# Altium schematic sheet 12V_Efuses2.SchDoc(12V_Efuses2)
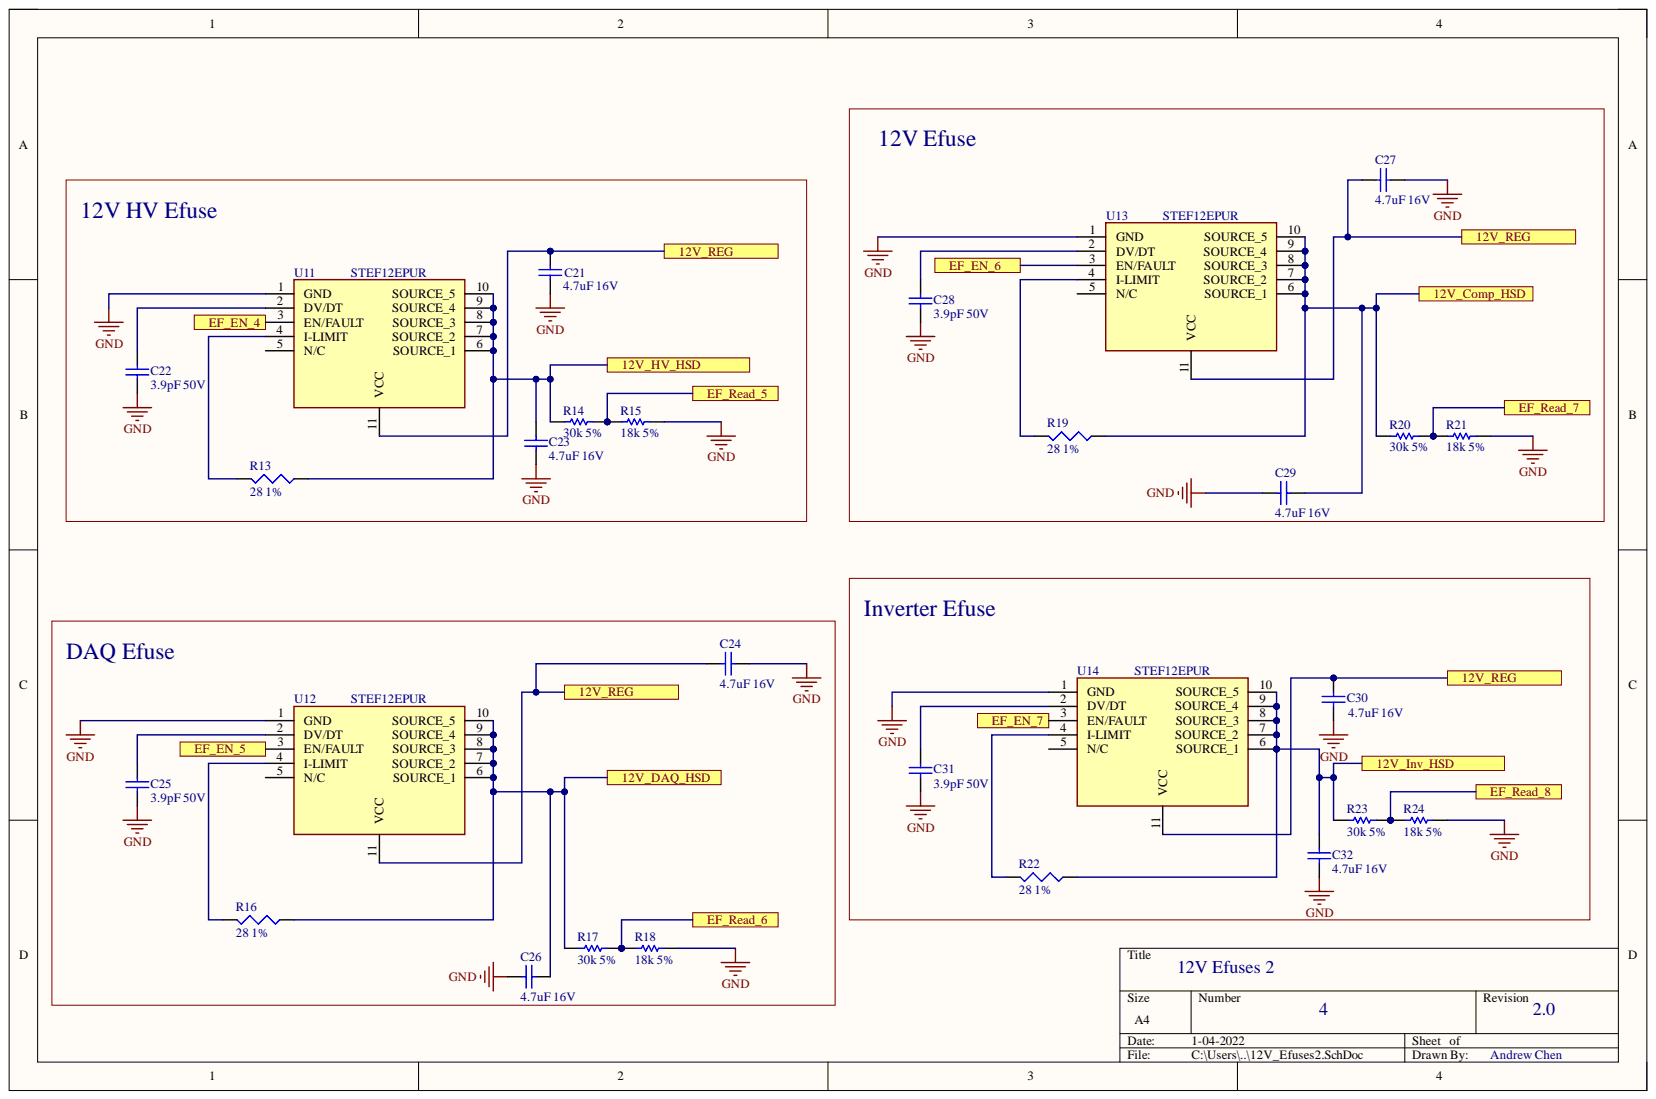
[diagram p0: 12V_Efuses2.SchDoc]

## Parts
C21  4.7uF 16V  [C1206]
C22  3.9pF 50V  [C1206]
C23  4.7uF 16V  [C1206]
C24  4.7uF 16V  [C1206]
C25  3.9pF 50V  [C1206]
C26  4.7uF 16V  [C1206]
C27  4.7uF 16V  [C1206]
C28  3.9pF 50V  [C1206]
C29  4.7uF 16V  [C1206]
C30  4.7uF 16V  [C1206]
C31  3.9pF 50V  [C1206]
C32  4.7uF 16V  [C1206]
R13  28 1%  [J1-0603]
R14  30k 5%  [RESC1609X50X30NL8T20]
R15  18k 5%  [RESC1609X50X30NL8T20]
R16  28 1%  [J1-0603]
R17  30k 5%  [RESC1609X50X30NL8T20]
R18  18k 5%  [RESC1609X50X30NL8T20]
R19  28 1%  [J1-0603]
R20  30k 5%  [RESC1609X50X30NL8T20]
R21  18k 5%  [RESC1609X50X30NL8T20]
R22  28 1%  [J1-0603]
R23  30k 5%  [RESC1609X50X30NL8T20]
R24  18k 5%  [RESC1609X50X30NL8T20]
U11  STEF12EPUR  [SON50P300X300X100-11N-D]
U12  STEF12EPUR  [SON50P300X300X100-11N-D]
U13  STEF12EPUR  [SON50P300X300X100-11N-D]
U14  STEF12EPUR  [SON50P300X300X100-11N-D]

## Ports
12V_Comp_HSD, 12V_DAQ_HSD, 12V_HV_HSD, 12V_Inv_HSD, 12V_REG, EF_EN_4, EF_EN_5, EF_EN_6, EF_EN_7, EF_Read_5, EF_Read_6, EF_Read_7, EF_Read_8

## Nets
GND: C21.2 C22.2 C23.2 C24.1 C25.2 C26.2 C27.1 C28.2 C29.2 C30.2 C31.2 C32.2 R15.2 R18.2 R21.2 R24.2 U11.1(GND) U12.1(GND) U13.1(GND) U14.1(GND)
12V_Comp_HSD: C29.1 R19.1 R20.1 U13.6(SOURCE_1) U13.7(SOURCE_2) U13.8(SOURCE_3) U13.9(SOURCE_4) U13.10(SOURCE_5) ; port 12V_Comp_HSD
12V_DAQ_HSD: C26.1 R16.1 R17.1 U12.6(SOURCE_1) U12.7(SOURCE_2) U12.8(SOURCE_3) U12.9(SOURCE_4) U12.10(SOURCE_5) ; port 12V_DAQ_HSD
12V_HV_HSD: C23.1 R13.1 R14.1 U11.6(SOURCE_1) U11.7(SOURCE_2) U11.8(SOURCE_3) U11.9(SOURCE_4) U11.10(SOURCE_5) ; port 12V_HV_HSD
12V_Inv_HSD: C32.1 R22.1 R23.1 U14.6(SOURCE_1) U14.7(SOURCE_2) U14.8(SOURCE_3) U14.9(SOURCE_4) U14.10(SOURCE_5) ; port 12V_Inv_HSD
12V_REG: C21.1 C24.2 C27.2 C30.1 U11.11(VCC) U12.11(VCC) U13.11(VCC) U14.11(VCC) ; port 12V_REG
EF_EN_4: U11.3(EN/FAULT) ; port EF_EN_4
EF_EN_5: U12.3(EN/FAULT) ; port EF_EN_5
EF_EN_6: U13.3(EN/FAULT) ; port EF_EN_6
EF_EN_7: U14.3(EN/FAULT) ; port EF_EN_7
EF_Read_5: R14.2 R15.1 ; port EF_Read_5
EF_Read_6: R17.2 R18.1 ; port EF_Read_6
EF_Read_7: R20.2 R21.1 ; port EF_Read_7
EF_Read_8: R23.2 R24.1 ; port EF_Read_8
NetC22_1: C22.1 U11.2(DV/DT)
NetC25_1: C25.1 U12.2(DV/DT)
NetC28_1: C28.1 U13.2(DV/DT)
NetC31_1: C31.1 U14.2(DV/DT)
NetR13_2: R13.2 U11.4(I-LIMIT)
NetR16_2: R16.2 U12.4(I-LIMIT)
NetR19_2: R19.2 U13.4(I-LIMIT)
NetR22_2: R22.2 U14.4(I-LIMIT)

Not connected: U11 5(N/C); U12 5(N/C); U13 5(N/C); U14 5(N/C)

## Library data of the parts
C21-C32 = Cap Semi: Capacitor (Semiconductor SIM Model); Value: 22pF; Component Kind: Standard; LatestRevisionDate: 29-May-2009; LatestRevisionNote: IPC-7351 Footprint Added.; Library Name: Miscellaneous Devices.IntLib; PackageDescription: Chip Capacitor; PackageReference: C1206; Published: 8-Jun-2000; Signal Integrity: Cap Semi; Simulation: CAP; Publisher: Altium Limited
R13 R16 R19 R22 = Res3: Resistor; Value: 220; Component Kind: Standard; LatestRevisionDate: 29-May-2009; LatestRevisionNote: IPC-7351 Footprint Added.; Library Name: Miscellaneous Devices.IntLib; PackageDescription: Chip Resistor; PackageReference: J1-0603; Published: 8-Jun-2000; Signal Integrity: Res; Simulation: RESISTOR; Publisher: Altium Limited
R14 R15 R17 R18 R20 R21 R23 R24 = CMP-2000-03816-5: CRCW06030000Z0EA; Manufacturer: Vishay Semiconductor; Voltage Rating: 75 V; Component Kind: Standard; ComponentLink1Description: Manufacturer URL; ComponentLink1URL: http://www.vishay.com/; ComponentLink2Description: Datasheet; ComponentLink2URL: http://www.vishay.com/docs/20035/dcrcwe3.pdf; DatasheetVersion: 06/2012; Height: 0.45 mm; Length: 1.55 mm; Library Name: Altium Content Vault; Max Operating Temperature: 155 degC; Min Operating Temperature: -55 degC; Mount: Surface Mount; Mounting Technology: Surface Mount; Package Quantity: 5000; PackageDescription: 2-Pin Surface Mount Device, Body 1.575 x 0.85 mm; PackageReference: 0603; Packaging: Tape and Reel; Pins: 2; REACH SVHC: Unknown; RoHSCompliant: true
U11-U14 = STEF12EPUR: Integrated Circuit; Manufacturer_Name: STMicroelectronics; Arrow Part Number: STEF12EPUR; Arrow Price/Stock: https://www.arrow.com/en/products/stef12epur/stmicroelectronics; Component Kind: Standard; Datasheet Link: https://www.arrow.com/en/products/stef12epur/stmicroelectronics; Height: 1mm; Manufacturer_Part_Number: STEF12EPUR; Mouser Part Number: 511-STEF12EPUR; Mouser Price/Stock: https://www.mouser.co.uk/ProductDetail/STMicroelectronics/STEF12EPUR?qs=mKNKSX85ZJfL9n%252BG1BPmuw%3D%3D
